# Altium schematic sheet [03] - MCU - RP2040.SchDoc("[03] - MCU - RP2040")
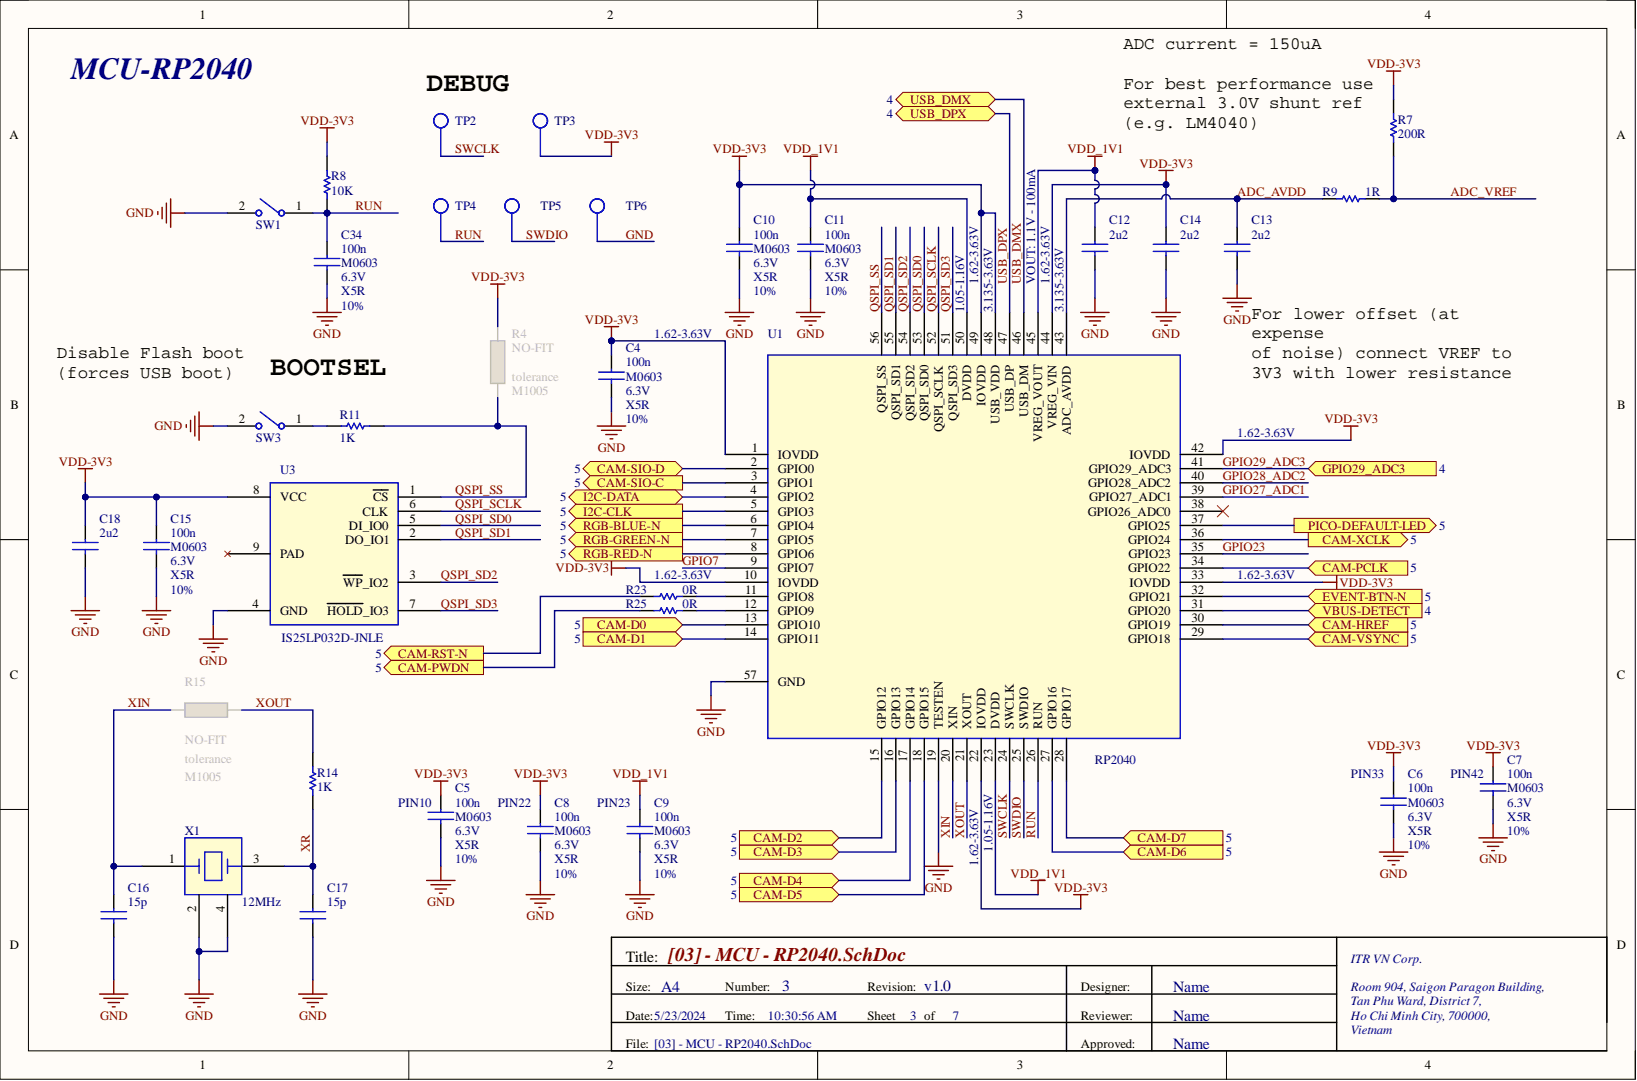
[diagram p0: [03] - MCU - RP2040.SchDoc]

## Parts
C4  100n  Dielectric: X5R  Package: M0603  Tolerance: 10%  Voltage: 6.3V  [CAPC1005X55_0402]
C5  100n  Dielectric: X5R  Package: M0603  Tolerance: 10%  Voltage: 6.3V  [CAPC1005X55_0402]
C6  100n  Dielectric: X5R  Package: M0603  Tolerance: 10%  Voltage: 6.3V  [CAPC1005X55_0402]
C7  100n  Dielectric: X5R  Package: M0603  Tolerance: 10%  Voltage: 6.3V  [CAPC1005X55_0402]
C8  100n  Dielectric: X5R  Package: M0603  Tolerance: 10%  Voltage: 6.3V  [CAPC1005X55_0402]
C9  100n  Dielectric: X5R  Package: M0603  Tolerance: 10%  Voltage: 6.3V  [CAPC1005X55_0402]
C10  100n  Dielectric: X5R  Package: M0603  Voltage: 6.3V  [CAPC1005X55_0402]
C11  100n  Dielectric: X5R  Package: M0603  Voltage: 6.3V  [CAPC1005X55_0402]
C12  2u2  [CAPC1005X55_0402]
C13  2u2  [CAPC1005X55_0402]
C14  2u2  [CAPC1005X55_0402]
C15  100n  Dielectric: X5R  Package: M0603  Tolerance: 10%  Voltage: 6.3V  [CAPC1005X55_0402]
C16  15p  [CAPC1005X55_0402]
C17  15p  [CAPC1005X55_0402]
C18  2u2  [CAPC1005X55_0402]
C34  100n  Dielectric: X5R  Package: M0603  Tolerance: 10%  Voltage: 6.3V  [CAPC1005X55_0402]
R4  NO-FIT  Package: M1005  [RESC1005X40_0402]
R7  value 200R  [RESC1005X40_0402]  (hidden comment: Resistor)
R8  value 10K  [RESC1005X40_0402]  (hidden comment: Resistor)
R9  value 1R  Resistance: 1R  [RESC1005X40_0402]  (hidden comment: Resistor)
R11  value 1K  [RESC1005X40_0402]  (hidden comment: Resistor)
R14  value 1K  [RESC1005X40_0402]  (hidden comment: Resistor)
R15  NO-FIT  [RESC1005X40_0402]
R23  value 0R  [RESC1005X40_0402]  (hidden comment: Resistor)
R25  value 0R  [RESC1005X40_0402]  (hidden comment: Resistor)
SW1  (no value)  [EVP-AKE31A]
SW3  (no value)  [EVP-AKE31A]
TP2  TP  [TEST_PAD-40MIL]  (no BOM)
TP3  TP  [TEST_PAD-40MIL]  (no BOM)
TP4  TP  [TEST_PAD-40MIL]  (no BOM)
TP5  TP  [TEST_PAD-40MIL]  (no BOM)
TP6  TP  [TEST_PAD-40MIL]  (no BOM)
U1  RP2040  [IC57_RP2040]
U3  IS25LP032D-JNLE  [SOIC127P400X175_8]
X1  12MHz  [OSCCC320X250X60]

## Ports
CAM-D0, CAM-D1, CAM-D2, CAM-D3, CAM-D4, CAM-D5, CAM-D6, CAM-D7, CAM-HREF, CAM-PCLK, CAM-PWDN, CAM-RST-N, CAM-SIO-C, CAM-SIO-D, CAM-VSYNC, CAM-XCLK, EVENT-BTN-N, GPIO29_ADC3, I2C-CLK, I2C-DATA, PICO-DEFAULT-LED, RGB-BLUE-N, RGB-GREEN-N, RGB-RED-N, USB_DMX, USB_DPX, VBUS-DETECT

## Nets
VDD-3V3: C4.1 C5.1 C6.1 C7.1 C8.1 C10.1 C14.1 C15.1 C18.1 R4.1 R7.2 R8.2 TP3.1 U1.1(IOVDD) U1.10(IOVDD) U1.22(IOVDD) U1.33(IOVDD) U1.42(IOVDD) U1.44(VREG_VIN) U1.48(USB_VDD) U1.49(IOVDD) U3.8(VCC)
VDD_1V1: C9.1 C11.1 C12.1 U1.23(DVDD) U1.45(VREG_VOUT) U1.50(DVDD)
ADC_AVDD: C13.1 R9.1 U1.43(ADC_AVDD)
ADC_VREF: R7.1 R9.2
CAM-D0: U1.13(GPIO10) ; port CAM-D0
CAM-D1: U1.14(GPIO11) ; port CAM-D1
CAM-D2: U1.15(GPIO12) ; port CAM-D2
CAM-D3: U1.16(GPIO13) ; port CAM-D3
CAM-D4: U1.17(GPIO14) ; port CAM-D4
CAM-D5: U1.18(GPIO15) ; port CAM-D5
CAM-D6: U1.27(GPIO16) ; port CAM-D6
CAM-D7: U1.28(GPIO17) ; port CAM-D7
CAM-HREF: U1.30(GPIO19) ; port CAM-HREF
CAM-PCLK: U1.34(GPIO22) ; port CAM-PCLK
CAM-PWDN: R25.1 ; port CAM-PWDN
CAM-RST-N: R23.1 ; port CAM-RST-N
CAM-SIO-C: U1.3(GPIO1) ; port CAM-SIO-C
CAM-SIO-D: U1.2(GPIO0) ; port CAM-SIO-D
CAM-VSYNC: U1.29(GPIO18) ; port CAM-VSYNC
CAM-XCLK: U1.36(GPIO24) ; port CAM-XCLK
EVENT-BTN-N: U1.32(GPIO21) ; port EVENT-BTN-N
GND: C4.2 C5.2 C6.2 C7.2 C8.2 C9.2 C10.2 C11.2 C12.2 C13.2 C14.2 C15.2 C16.2 C17.2 C18.2 C34.2 SW1.2 SW3.2 TP6.1 U1.19(TESTEN) U1.57(GND) U3.4(GND) X1.2 X1.4
GPIO7: U1.9(GPIO7)
GPIO23: U1.35(GPIO23)
GPIO27_ADC1: U1.39(GPIO27_ADC1)
GPIO28_ADC2: U1.40(GPIO28_ADC2)
GPIO29_ADC3: U1.41(GPIO29_ADC3) ; port GPIO29_ADC3
I2C-CLK: U1.5(GPIO3) ; port I2C-CLK
I2C-DATA: U1.4(GPIO2) ; port I2C-DATA
PICO-DEFAULT-LED: U1.37(GPIO25) ; port PICO-DEFAULT-LED
QSPI_SCLK: U1.52(QSPI_SCLK) U3.6(CLK)
QSPI_SD0: U1.53(QSPI_SD0) U3.5(DI_IO0)
QSPI_SD1: U1.55(QSPI_SD1) U3.2(DO_IO1)
QSPI_SD2: U1.54(QSPI_SD2) U3.3(WP_IO2)
QSPI_SD3: U1.51(QSPI_SD3) U3.7(HOLD_IO3)
QSPI_SS: R4.2 R11.1 U1.56(QSPI_SS) U3.1(CS)
RGB-BLUE-N: U1.6(GPIO4) ; port RGB-BLUE-N
RGB-GREEN-N: U1.7(GPIO5) ; port RGB-GREEN-N
RGB-RED-N: U1.8(GPIO6) ; port RGB-RED-N
RUN: C34.1 R8.1 SW1.1 TP4.1 U1.26(RUN)
SWCLK: TP2.1 U1.24(SWCLK)
SWDIO: TP5.1 U1.25(SWDIO)
USB_DMX: U1.46(USB_DM) ; port USB_DMX
USB_DPX: U1.47(USB_DP) ; port USB_DPX
VBUS-DETECT: U1.31(GPIO20) ; port VBUS-DETECT
XIN: C16.1 R15.1 U1.20(XIN) X1.1
XOUT: R14.2 R15.2 U1.21(XOUT)
XR: C17.1 R14.1 X1.3
NetR11_2: R11.2 SW3.1
NetR23_2: R23.2 U1.11(GPIO8)
NetR25_2: R25.2 U1.12(GPIO9)

Not connected: U1 38(GPIO26_ADC0); U3 9(PAD)

## Library data of the parts
C4-C9 C15 C34 = CAP_MLCC: Surface Mount Ceramic Multilayer Capacitor 0.1uF -20% to 80% Y5V 16V 0402 Paper T/R; Manufacturer 1: Yageo; Manufacturer Part Number 1: CC0402ZRY5V7BB104; Capacitance: 100nF; Case Code (Imperial): 0402; Case Code (Metric): 1005; Case/Package: 0402; Category: Ceramic Capacitors; Component Kind: Standard; ComponentLink1Description: https://datasheet.ciiva.com/143/mlccorderingcode-143948.pdf?src-supplier=Digi-Key; ComponentLink1URL: https://datasheet.ciiva.com/143/mlccorderingcode-143948.pdf?src-supplier=Digi-Key; ComponentLink2Description: https://datasheet.ciiva.com/143/mlccorderingcode-143947.pdf?src-supplier=Digi-Key; ComponentLink2URL: https://datasheet.ciiva.com/143/mlccorderingcode-143947.pdf?src-supplier=Digi-Key; ComponentLink3Description: https://datasheet.ciiva.com/143/mlccorderingcode-143949.pdf?src-supplier=Digi-Key; ComponentLink3URL: https://datasheet.ciiva.com/143/mlccorderingcode-143949.pdf?src-supplier=Digi-Key; ComponentLink4Description: https://datasheet.ciiva.com/26862/getdatasheetpartid-403483-26862665.pdf?src-supplier=Verical; ComponentLink4URL: https://datasheet.ciiva.com/26862/getdatasheetpartid-403483-26862665.pdf?src-supplier=Verical; ComponentLink5Description: https://datasheet.ciiva.com/7978/1132289-7978122.pdf?src-supplier=Element14; ComponentLink5URL: https://datasheet.ciiva.com/7978/1132289-7978122.pdf?src-supplier=Element14; ComponentLink6Description: https://datasheet.ciiva.com/28450/1132289-28450424.pdf?src-supplier=Element14; ComponentLink6URL: https://datasheet.ciiva.com/28450/1132289-28450424.pdf?src-supplier=Element14; ComponentLink7Description: https://datasheet.ciiva.com/8033/1132289-8033645.pdf?src-supplier=Element14; ComponentLink7URL: https://datasheet.ciiva.com/8033/1132289-8033645.pdf?src-supplier=Element14; ComponentLink8Description: https://datasheet.ciiva.com/8033/1132289-8033647.pdf?src-supplier=Element14; ComponentLink8URL: https://datasheet.ciiva.com/8033/1132289-8033647.pdf?src-supplier=Element14; ComponentLink9Description: https://datasheet.ciiva.com/13386/upy-gphc-y5v-6-3v-to-50v-5-13386335.pdf?src-supplier=Mouser; ComponentLink9URL: https://datasheet.ciiva.com/13386/upy-gphc-y5v-6-3v-to-50v-5-13386335.pdf?src-supplier=Mouser; ComponentLink10Description: https://datasheet.ciiva.com/11586/upy-gphc-y5v-6-3v-to-50v-5-11586519.pdf?src-supplier=Avnet; ComponentLink10URL: https://datasheet.ciiva.com/11586/upy-gphc-y5v-6-3v-to-50v-5-11586519.pdf?src-supplier=Avnet; Depth: 0.5mm; Height: 0.5mm; Lead Free: 5697LeadFree; Length: 1mm; Max Operating Temperature: 85°C; Min Operating Temperature: -30°C; Mount: Surface Mount; Package Quantity: 10000; Packaging: Tape and Reel; Pricing 1: 1=0.1, 10=0.017, 50=0.0092, 100=0.0075, 500=0.00538, 1000=0.00422, 2500=0.00384, 5000=0.00353 (USD); Radiation Hardening: No; Reach SVHC Compliant: No; RoHS: Yes; RoHS Compliant: Yes; Series: CC; Stock 1: 245770; Supplier 1: Digi-Key; Supplier 2: LCSC.com; Supplier Part Number 1: 311-1047-1-ND; Supplier Part Number 2: C106209; Termination: SMD/SMT; Voltage Rating: 16V; Voltage Rating (AC): 16V; Voltage Rating (DC): 16V; Width: 0.5mm
C10 C11 = CAP_MLCC: Surface Mount Ceramic Multilayer Capacitor 0.1uF -20% to 80% Y5V 16V 0402 Paper T/R; Manufacturer 1: Yageo; Manufacturer Part Number 1: CC0402ZRY5V7BB104; Capacitance: 100nF; Tolerance: 10%; Case Code (Imperial): 0402; Case Code (Metric): 1005; Case/Package: 0402; Category: Ceramic Capacitors; Component Kind: Standard; ComponentLink1Description: https://datasheet.ciiva.com/143/mlccorderingcode-143948.pdf?src-supplier=Digi-Key; ComponentLink1URL: https://datasheet.ciiva.com/143/mlccorderingcode-143948.pdf?src-supplier=Digi-Key; ComponentLink2Description: https://datasheet.ciiva.com/143/mlccorderingcode-143947.pdf?src-supplier=Digi-Key; ComponentLink2URL: https://datasheet.ciiva.com/143/mlccorderingcode-143947.pdf?src-supplier=Digi-Key; ComponentLink3Description: https://datasheet.ciiva.com/143/mlccorderingcode-143949.pdf?src-supplier=Digi-Key; ComponentLink3URL: https://datasheet.ciiva.com/143/mlccorderingcode-143949.pdf?src-supplier=Digi-Key; ComponentLink4Description: https://datasheet.ciiva.com/26862/getdatasheetpartid-403483-26862665.pdf?src-supplier=Verical; ComponentLink4URL: https://datasheet.ciiva.com/26862/getdatasheetpartid-403483-26862665.pdf?src-supplier=Verical; ComponentLink5Description: https://datasheet.ciiva.com/7978/1132289-7978122.pdf?src-supplier=Element14; ComponentLink5URL: https://datasheet.ciiva.com/7978/1132289-7978122.pdf?src-supplier=Element14; ComponentLink6Description: https://datasheet.ciiva.com/28450/1132289-28450424.pdf?src-supplier=Element14; ComponentLink6URL: https://datasheet.ciiva.com/28450/1132289-28450424.pdf?src-supplier=Element14; ComponentLink7Description: https://datasheet.ciiva.com/8033/1132289-8033645.pdf?src-supplier=Element14; ComponentLink7URL: https://datasheet.ciiva.com/8033/1132289-8033645.pdf?src-supplier=Element14; ComponentLink8Description: https://datasheet.ciiva.com/8033/1132289-8033647.pdf?src-supplier=Element14; ComponentLink8URL: https://datasheet.ciiva.com/8033/1132289-8033647.pdf?src-supplier=Element14; ComponentLink9Description: https://datasheet.ciiva.com/13386/upy-gphc-y5v-6-3v-to-50v-5-13386335.pdf?src-supplier=Mouser; ComponentLink9URL: https://datasheet.ciiva.com/13386/upy-gphc-y5v-6-3v-to-50v-5-13386335.pdf?src-supplier=Mouser; ComponentLink10Description: https://datasheet.ciiva.com/11586/upy-gphc-y5v-6-3v-to-50v-5-11586519.pdf?src-supplier=Avnet; ComponentLink10URL: https://datasheet.ciiva.com/11586/upy-gphc-y5v-6-3v-to-50v-5-11586519.pdf?src-supplier=Avnet; Depth: 0.5mm; Height: 0.5mm; Lead Free: 5697LeadFree; Length: 1mm; Max Operating Temperature: 85°C; Min Operating Temperature: -30°C; Mount: Surface Mount; Package Quantity: 10000; Packaging: Tape and Reel; Pricing 1: 1=0.1, 10=0.017, 50=0.0092, 100=0.0075, 500=0.00538, 1000=0.00422, 2500=0.00384, 5000=0.00353 (USD); Radiation Hardening: No; Reach SVHC Compliant: No; RoHS: Yes; RoHS Compliant: Yes; Series: CC; Stock 1: 245770; Supplier 1: Digi-Key; Supplier 2: LCSC.com; Supplier Part Number 1: 311-1047-1-ND; Supplier Part Number 2: C106209; Termination: SMD/SMT; Voltage Rating: 16V; Voltage Rating (AC): 16V; Voltage Rating (DC): 16V; Width: 0.5mm
C12-C14 C18 = CAP_MLCC: Multilayer Ceramic Capacitors 0402 10V X5R 225 20% 7" PAPER; Manufacturer 1: Murata; Manufacturer Part Number 1: GRM155R61A225ME01D; Value: 2.2uF; Category: Ceramic Capacitors; Component Kind: Standard; Pricing 1: 1=0.1, 10=0.046, 50=0.0252, 100=0.0205, 500=0.01466, 1000=0.01151, 2500=0.01046, 5000=0.00963 (USD); RoHS: Yes; Stock 1: 14798; Supplier 1: Digi-Key; Supplier 2: LCSC.com; Supplier Part Number 1: 490-GRM155R61A225ME01DCT-ND; Supplier Part Number 2: C385031
C16 C17 = CAP_MLCC: Multilayer Ceramic Capacitor, 15 pF, 10 V, ± 5%, X7R, 0402 [1005 Metric]; Manufacturer 1: Yageo; Manufacturer Part Number 1: CC0402JRNPO9BN150; Capacitance: 15pF; Dielectric: C0G; Insulation Resistance: 100GR; Value: 15pF; Voltage: 50V; Case Code (Imperial): 0402; Case Code (Metric): 1005; Case/Package: 0402; Category: Ceramic Capacitors; Component Kind: Standard; ComponentLink1Description: https://datasheet.ciiva.com/29538/partnumbering-e-01-29538956.pdf?src-supplier=Digi-Key; ComponentLink1URL: https://datasheet.ciiva.com/29538/partnumbering-e-01-29538956.pdf?src-supplier=Digi-Key; ComponentLink2Description: https://datasheet.ciiva.com/41860/partnumbering-e-01-41860774.pdf?src-supplier=Digi-Key; ComponentLink2URL: https://datasheet.ciiva.com/41860/partnumbering-e-01-41860774.pdf?src-supplier=Digi-Key; ComponentLink3Description: https://datasheet.ciiva.com/5789/1443161-5789504.pdf?src-supplier=Farnell; ComponentLink3URL: https://datasheet.ciiva.com/5789/1443161-5789504.pdf?src-supplier=Farnell; ComponentLink4Description: https://datasheet.ciiva.com/26862/getdatasheetpartid-403449-26862595.pdf?src-supplier=Verical; ComponentLink4URL: https://datasheet.ciiva.com/26862/getdatasheetpartid-403449-26862595.pdf?src-supplier=Verical; ComponentLink5Description: https://datasheet.ciiva.com/144/mlccorderingcode-144002.pdf?src-supplier=Digi-Key; ComponentLink5URL: https://datasheet.ciiva.com/144/mlccorderingcode-144002.pdf?src-supplier=Digi-Key; ComponentLink6Description: https://datasheet.ciiva.com/144/mlccorderingcode-144001.pdf?src-supplier=Digi-Key; ComponentLink6URL: https://datasheet.ciiva.com/144/mlccorderingcode-144001.pdf?src-supplier=Digi-Key; ComponentLink7Description: https://datasheet.ciiva.com/144/mlccorderingcode-144003.pdf?src-supplier=Digi-Key; ComponentLink7URL: https://datasheet.ciiva.com/144/mlccorderingcode-144003.pdf?src-supplier=Digi-Key; ComponentLink8Description: https://datasheet.ciiva.com/13386/upy-gp-np0-16v-to-50v-8-13386330.pdf?src-supplier=Mouser; ComponentLink8URL: https://datasheet.ciiva.com/13386/upy-gp-np0-16v-to-50v-8-13386330.pdf?src-supplier=Mouser; ComponentLink9Description: https://datasheet.ciiva.com/11587/upy-gp-np0-16v-to-50v-8-11587085.pdf?src-supplier=Avnet; ComponentLink9URL: https://datasheet.ciiva.com/11587/upy-gp-np0-16v-to-50v-8-11587085.pdf?src-supplier=Avnet; Depth: 0.5mm; Dielectric Material: Ceramic; Height: 0.5mm; Lead Free: Lead Free; Length: 1mm; Max Operating Temperature: 125°C; Min Operating Temperature: -55°C; Mount: Surface Mount; Number of Pins: 2; Package Quantity: 1; Packaging: Tape and Reel; Pricing 1: 1=0.1, 10=0.024, 50=0.013, 100=0.0107, 500=0.00764, 1000=0.006, 2500=0.00546, 5000=0.00502 (USD); Radiation Hardening: No; Reach SVHC Compliant: No; RoHS: Yes; RoHS Compliant: Yes; Series: CC; Stock 1: 1017669; Supplier 1: Digi-Key; Supplier 2: LCSC.com; Supplier Part Number 1: 311-1017-1-ND; Supplier Part Number 2: C106997; Termination: SMD/SMT; Thickness: 0.022inch; Tolerance: 5%; Voltage Rating: 50V; Voltage Rating (DC): 50V; Width: 0.02inch
R4 R15 = RES_CHIP: Resistor, Chip, M1005, NOT FITTED; PCB Footprint: resc1005; Component Kind: Standard; status: OK; Tolerance: tolerance
  R15: Package: M1005
R7 = Resistor: SMD Chip Resistor, 200 Ohm, ± 1%, 63 mW, 0402 [1005 Metric], Thick Film, General Purpose; Manufacturer 1: Yageo; Manufacturer Part Number 1: AC0402FR-07200RL; Symbol status: Released; Category: Chip SMD Resistors; Component Kind: Standard; ComponentLink1Description: https://www.yageo.com/upload/media/product/productsearch/datasheet/rchip/PYu-AC_51_RoHS_L_7.pdf?src-supplier=Digi-Key; ComponentLink1URL: https://www.yageo.com/upload/media/product/productsearch/datasheet/rchip/PYu-AC_51_RoHS_L_7.pdf?src-supplier=Digi-Key; ComponentLink2Description: http://www.yageo.com/NewPortal/yageodocoutput?fileName=/pdf/R-Chip/PYu-AC_51_RoHS_L_6.pdf&src-supplier=Digi-Key; ComponentLink2URL: http://www.yageo.com/NewPortal/yageodocoutput?fileName=/pdf/R-Chip/PYu-AC_51_RoHS_L_6.pdf&src-supplier=Digi-Key; ComponentLink3Description: https://datasheet.octopart.com/AC0402FR-07200RL-Yageo-datasheet-151884373.pdf?src-supplier=Future+Electronics; ComponentLink3URL: https://datasheet.octopart.com/AC0402FR-07200RL-Yageo-datasheet-151884373.pdf?src-supplier=Future+Electronics; ComponentLink4Description: http://www.yageo.com/documents/recent/PYu-AC_51_RoHS_L_2_revised.pdf?src-supplier=Future+Electronics; ComponentLink4URL: http://www.yageo.com/documents/recent/PYu-AC_51_RoHS_L_2_revised.pdf?src-supplier=Future+Electronics; Library Name: Miscellaneous_Devices.SchLib; Pricing 1: 1=0.1, 10=0.018, 50=0.011, 100=0.009, 500=0.00606, 1000=0.00517, 5000=0.00371 (USD); RoHS: Yes; Stock 1: 64935; Supplier 1: Digi-Key; Supplier 2: LCSC.com; Supplier Part Number 1: YAG3453CT-ND; Supplier Part Number 2: C144784
R8 = Res1: RES SMD 10K OHM 5% 1/10W 0402; Manufacturer 1: Panasonic; Manufacturer Part Number 1: ERJ-2GEJ103X; BOM Type: A; Max Power Dissipation: 100mW; Resistance: 10kR; Temperature Coefficient: 200ppm/°C; Voltage Rating: 50V; Case Code (Imperial): 0402; Case Code (Metric): 1005; Case/Package: 0402; Category: Chip SMD Resistors; Component Kind: Standard; ComponentLink1Description: https://datasheet.ciiva.com/11821/0900766b80f806dd-11821170.pdf?src-supplier=RSComponents; ComponentLink1URL: https://datasheet.ciiva.com/11821/0900766b80f806dd-11821170.pdf?src-supplier=RSComponents; ComponentLink2Description: https://datasheet.ciiva.com/27055/1935721-27055112.pdf?src-supplier=Jameco; ComponentLink2URL: https://datasheet.ciiva.com/27055/1935721-27055112.pdf?src-supplier=Jameco; ComponentLink3Description: https://datasheet.ciiva.com/17212/aoa0000ce1-17212101.pdf?src-supplier=Avnet+Europe; ComponentLink3URL: https://datasheet.ciiva.com/17212/aoa0000ce1-17212101.pdf?src-supplier=Avnet+Europe; ComponentLink4Description: https://datasheet.ciiva.com/46371/1678796-46371910.pdf?src-supplier=Element14; ComponentLink4URL: https://datasheet.ciiva.com/46371/1678796-46371910.pdf?src-supplier=Element14; ComponentLink5Description: https://datasheet.ciiva.com/24070/aoa0000ce1-37422-24070679.pdf?src-supplier=Mouser; ComponentLink5URL: https://datasheet.ciiva.com/24070/aoa0000ce1-37422-24070679.pdf?src-supplier=Mouser; ComponentLink6Description: https://datasheet.ciiva.com/43460/1678796-43460391.pdf?src-supplier=Farnell; ComponentLink6URL: https://datasheet.ciiva.com/43460/1678796-43460391.pdf?src-supplier=Farnell; ComponentLink7Description: https://datasheet.ciiva.com/16774/70260739-16774504.pdf?src-supplier=Allied+Electronics; ComponentLink7URL: https://datasheet.ciiva.com/16774/70260739-16774504.pdf?src-supplier=Allied+Electronics; Contact Plating: Tin; Depth: 0.5mm; Features: Automotive AEC-Q200; Height: 0.35mm; LatestRevisionDate: 17-Jul-2002; LatestRevisionNote: Re-released for DXP Platform.; Lead Free: Lead Free; Length: 1mm; Library Name: Miscellaneous Devices.IntLib; Max Operating Temperature: 155°C; Military Standard: Not; Min Operating Temperature: -55°C; Mount: Surface Mount; Number of Terminations: 2; Package Quantity: 1; PackageDescription: Axial Device, Thru-Hole; 2 Leads; 0.3 in Pin Spacing; PackageReference: AXIAL-0.3; Packaging: Cut Tape; Power Rating: 100mW; Pricing 1: 1=0.10000, 10=0.01900, 100=0.00770, 1000=0.00345, 2500=0.00299, 5000=0.00247 (USD); Published: 8-Jun-2000; Radiation Hardening: No; REACH SVHC: No SVHC; Resistor Type: Thick Film; RoHS: Yes; Signal Integrity: Res; Simulation: RESISTOR; Stock 1: 2048955; Supplier 1: Digi-Key; Supplier 2: LCSC.com; Supplier Part Number 1: P10KJCT-ND; Supplier Part Number 2: C278577; Termination: SMD/SMT; Tolerance: 5%; Voltage Rating (DC): 50V; Width: 0.02inch; Publisher: Altium Limited
R9 = Resistor: SMD Chip Resistor, 1 Ohm, ± 5%, 63 mW, 0402 [1005 Metric], Thick Film, General Purpose; Manufacturer 1: Yageo; Manufacturer Part Number 1: RC0402JR-071RL; Max Power Dissipation: 63mW; Power Rating: 62.5mW; Symbol status: Released; Temperature Coefficient: 200ppm/°C; Voltage Rating: 50V; Case Code (Imperial): 0402; Case Code (Metric): 1005; Case/Package: 0402; Category: Chip SMD Resistors; Component Kind: Standard; ComponentLink1Description: https://datasheet.ciiva.com/7983/1018594-7983561.pdf?src-supplier=Element14; ComponentLink1URL: https://datasheet.ciiva.com/7983/1018594-7983561.pdf?src-supplier=Element14; ComponentLink2Description: https://datasheet.ciiva.com/16747/pyu-rc0402-51-rohs-l-6-r-16747305.pdf?src-supplier=Future+Electronics; ComponentLink2URL: https://datasheet.ciiva.com/16747/pyu-rc0402-51-rohs-l-6-r-16747305.pdf?src-supplier=Future+Electronics; Features: Moisture Resistant; Lead Free: Lead Free; Library Name: Miscellaneous_Devices.SchLib; Max Operating Temperature: 155°C; Min Operating Temperature: -55°C; Number of Terminations: 2; Packaging: Tape and Reel; Pricing 1: 1=0.1, 10=0.016, 50=0.0096, 100=0.008, 500=0.00532, 1000=0.00454, 5000=0.00326 (USD); Reach SVHC Compliant: Unknown; Resistance Tolerance: 5%; Resistor Type: Thick Film; RoHS: Yes; RoHS Compliant: Yes; Series: RC; Size Code: 0402; Stock 1: 489706; Supplier 1: Digi-Key; Supplier 2: LCSC.com; Supplier Part Number 1: 311-1.0JRCT-ND; Supplier Part Number 2: C137904; Termination: SMD/SMT; Tolerance: 5%; Voltage Rating (DC): 50V
R11 R14 = Resistor: SMD Chip Resistor, 1 kOhm, ± 5%, 63 mW, 0402 [1005 Metric], Thick Film, General Purpose; Manufacturer 1: Yageo; Manufacturer Part Number 1: RC0402JR-071KL; Max Power Dissipation: 63mW; Power Rating: 62.5mW; Resistance: 1kR; Symbol status: Released; Temperature Coefficient: 100ppm/°C; Voltage Rating: 50V; Case Code (Imperial): 0402; Case Code (Metric): 1005; Case/Package: 0402; Category: Chip SMD Resistors; Component Kind: Standard; ComponentLink1Description: http://www.farnell.com/datasheets/2167052.pdf?src-supplier=Newark; ComponentLink1URL: http://www.farnell.com/datasheets/2167052.pdf?src-supplier=Newark; Features: Moisture Resistant; Height: 0.016inch; Lead Free: Lead Free; Length: 0.039inch; Library Name: Miscellaneous_Devices.SchLib; Max Operating Temperature: 155°C; Min Operating Temperature: -55°C; Number of Terminations: 2; Package Quantity: 1; Packaging: Cut Tape; Pricing 1: 1=0.1, 10=0.018, 50=0.0098, 100=0.0079, 500=0.00502, 1000=0.00422, 5000=0.00292 (USD); Reach SVHC Compliant: No; Resistance Tolerance: 5%; Resistor Type: Thick Film; RoHS: Yes; RoHS Compliant: Yes; Series: RC; Size Code: 0402; Stock 1: 18007002; Supplier 1: Digi-Key; Supplier 2: LCSC.com; Supplier Part Number 1: 311-1.0KJRDKR-ND; Supplier Part Number 2: C105637; Termination: SMD/SMT; Tolerance: 5%; Voltage Rating (AC): 50V; Width: 0.02inch
R23 R25 = Res1: PANASONIC - ERJ2GE0R00X - RES, 0R0, 0.1W, 0402, REEL; Manufacturer Part Number 1: ERJ-2GE0R00X; BOM Type: A; Max Power Dissipation: 100mW; Resistance: 0mR; Temperature Coefficient: 600ppm/°C; Tolerance: 5%; Voltage Rating: 50V; Case Code (Imperial): 0402; Case Code (Metric): 1005; Case/Package: 0402; Category: Chip SMD Resistors; Component Kind: Standard; ComponentLink1Description: https://datasheet.ciiva.com/11821/0900766b80f806dd-11821176.pdf?src-supplier=RSComponents; ComponentLink1URL: https://datasheet.ciiva.com/11821/0900766b80f806dd-11821176.pdf?src-supplier=RSComponents; ComponentLink2Description: https://datasheet.ciiva.com/48531/aoa0000ce1-48531077.pdf?src-supplier=Avnet; ComponentLink2URL: https://datasheet.ciiva.com/48531/aoa0000ce1-48531077.pdf?src-supplier=Avnet; ComponentLink3Description: https://datasheet.ciiva.com/16783/70260739-16783734.pdf?src-supplier=Allied+Electronics; ComponentLink3URL: https://datasheet.ciiva.com/16783/70260739-16783734.pdf?src-supplier=Allied+Electronics; ComponentLink4Description: https://datasheet.ciiva.com/17215/aoa0000ce1-17215386.pdf?src-supplier=Avnet+Europe; ComponentLink4URL: https://datasheet.ciiva.com/17215/aoa0000ce1-17215386.pdf?src-supplier=Avnet+Europe; ComponentLink5Description: https://datasheet.ciiva.com/43460/1678796-43460347.pdf?src-supplier=Farnell; ComponentLink5URL: https://datasheet.ciiva.com/43460/1678796-43460347.pdf?src-supplier=Farnell; ComponentLink6Description: https://datasheet.ciiva.com/5959/1678796-5959692.pdf?src-supplier=Farnell; ComponentLink6URL: https://datasheet.ciiva.com/5959/1678796-5959692.pdf?src-supplier=Farnell; ComponentLink7Description: https://datasheet.ciiva.com/30097/4aoa0000ce1-30097116.pdf?src-supplier=Onlinecomponents.com; ComponentLink7URL: https://datasheet.ciiva.com/30097/4aoa0000ce1-30097116.pdf?src-supplier=Onlinecomponents.com; ComponentLink8Description: https://datasheet.ciiva.com/28695/1678796-28695758.pdf?src-supplier=Element14; ComponentLink8URL: https://datasheet.ciiva.com/28695/1678796-28695758.pdf?src-supplier=Element14; ComponentLink9Description: https://datasheet.ciiva.com/46389/1678796-46389518.pdf?src-supplier=Element14; ComponentLink9URL: https://datasheet.ciiva.com/46389/1678796-46389518.pdf?src-supplier=Element14; Contact Plating: Tin; Depth: 0.5mm; Features: Automotive AEC-Q200; Height: 0.35mm; Lead Free: Lead Free; Length: 1mm; Library Name: Miscellaneous Devices.IntLib; Manufacturer 1: Panasonic; Max Operating Temperature: 155°C; Military Standard: Not; Min Operating Temperature: -55°C; Mount: Surface Mount; Number of Terminations: 2; Package Quantity: 1; Packaging: Tape and Reel; Power Rating: 100mW; Pricing 1: 1=0.10000, 10=0.01900, 25=0.01360, 50=0.01040, 100=0.00770, 250=0.00584, 500=0.00468, 1000=0.00345, 5000=0.00247 (USD); Radiation Hardening: No; REACH SVHC: No SVHC; Resistor Type: Thick Film; RoHS: Yes; Signal Integrity: Res; Simulation: RESISTOR; Stock 1: 15318658; Supplier 1: Digi-Key; Supplier 2: LCSC.com; Supplier Part Number 1: P0.0JCT-ND; Supplier Part Number 2: C242160; Termination: SMD/SMT; Voltage Rating (DC): 50V; Width: 0.02inch
SW1 SW3 = SPDT Toggle Switch 1: 3.8MM X 1.9MM / 1.6N / 0.12MM ST; Manufacturer 1: Panasonic; Illumination Type: Non-Illuminated; Mechanical Life: 500000Cycles; Operating Force: 160gf; Ratings: IP67,Waterproof,Dust Tight; Switch Function: Off-Mom; Symbol status: Released; Throw Configuration: SPST; Voltage Rating: 15V; Component Kind: Standard; ComponentLink1Description: https://industrial.panasonic.com/ac/cdn/e/control/switch/light-touch/catalog/sw_lt_eng_3819s_side.pdf?src-supplier=Digi-Key; ComponentLink1URL: https://industrial.panasonic.com/ac/cdn/e/control/switch/light-touch/catalog/sw_lt_eng_3819s_side.pdf?src-supplier=Digi-Key; Library Name: Miscellaneous_Devices.SchLib; Manufacturer Part Number 1: EVP-AKE31A; Max Operating Temperature: 85°C; Min Operating Temperature: -40°C; Mount: Surface Mount; Package / Case: SMT; Packaging: Cut Tape; Pricing 1: 1=0.61000, 10=0.58500, 25=0.56360, 100=0.48760, 250=0.44424, 500=0.41174, 1000=0.36839, 2500=0.34889 (USD); RoHS: No; Stock 1: 9109; Supplier 1: Digi-Key; Supplier Part Number 1: P123437CT-ND; Switch Travel: 0.12mm; Termination: SMD/SMT
U1 = RPI_RP2040: ARM Microcontrollers - MCU IC RP2040 (RP2B2)T&R, 3, 400 (13" reel)UICC01104; Manufacturer 1: Raspberry Pi; Manufacturer Part Number 1: SC0914(13); Category: Uncategorized; Component Kind: Standard; PCB Footprint: rpi_rp2040; Pricing 1: 1=0.7 (USD); RoHS: No; Stock 1: 56091; Supplier 1: Digi-Key; Supplier 2: LCSC.com; Supplier Part Number 1: 2648-SC0914(13)CT-ND; Supplier Part Number 2: C2040
U3 = WINBOND_W25X_QSPI_FLASH_USON: NOR Flash Serial (SPI, Dual SPI, Quad SPI) 2.5V/3V/3.3V 32M-bit 4M x 8 8ns 8-Pin SOIC N; Manufacturer 1: ISSI; Category: Memory; Component Kind: Standard; ComponentLink1Description: http://www.farnell.com/datasheets/2366874.pdf?src-supplier=Farnell; ComponentLink1URL: http://www.farnell.com/datasheets/2366874.pdf?src-supplier=Farnell; ComponentLink2Description: http://www.issi.com/WW/pdf/25LP-WP032D.pdf?src-supplier=Digi-Key; ComponentLink2URL: http://www.issi.com/WW/pdf/25LP-WP032D.pdf?src-supplier=Digi-Key; ComponentLink3Description: http://www.farnell.com/datasheets/2366874.pdf?src-supplier=Newark; ComponentLink3URL: http://www.farnell.com/datasheets/2366874.pdf?src-supplier=Newark; ComponentLink4Description: http://www.farnell.com/datasheets/2366874.pdf?src-supplier=Element14; ComponentLink4URL: http://www.farnell.com/datasheets/2366874.pdf?src-supplier=Element14; Manufacturer Part Number 1: IS25LP032D-JNLE; Pricing 1: 1=0.78, 10=0.714, 25=0.7056, 50=0.7014, 100=0.6271, 250=0.6218, 500=0.61262, 1000=0.5907, 5000=0.53803 (USD); RoHS: No; Stock 1: 1168; Supplier 1: Digi-Key; Supplier Part Number 1: 706-1585-ND
X1 = CRYSTAL_4P: Crystal 12MHz ±10ppm (Tol) ±10ppm (Stability) 10pF FUND 100Ohm 4-Pin Mini-CSMD T/R; Manufacturer 1: ECS International; Manufacturer Part Number 1: ECS-120-10-33B-CKM-TR; ESR (Equivalent Series Resistance): 100R; Case/Package: SMD/SMT; Component Kind: Standard; ComponentLink1Description: https://www.ecsxtal.com/store/pdf/ECS-33B.pdf?src-supplier=Digi-Key; ComponentLink1URL: https://www.ecsxtal.com/store/pdf/ECS-33B.pdf?src-supplier=Digi-Key; Frequency: 12MHz; Frequency Stability: 10ppm; Frequency Tolerance: 10ppm; Load Capacitance: 10pF; Max Operating Temperature: 70°C; Min Operating Temperature: -20°C; Mount: Surface Mount; Packaging: Cut Tape; Pricing 1: 1=0.48, 10=0.403, 50=0.3624, 100=0.3222, 500=0.30606 (USD); RoHS: Yes; RoHS Compliant: Yes; Series: ECS-33B; Stock 1: 56288; Supplier 1: Digi-Key; Supplier 2: LCSC.com; Supplier Part Number 1: XC2426CT-ND; Supplier Part Number 2: C2450718
TP2-TP6 = TP: Library Name: Pico Camera.SchLib
